# Altium schematic sheet conn_board_power.SchDoc
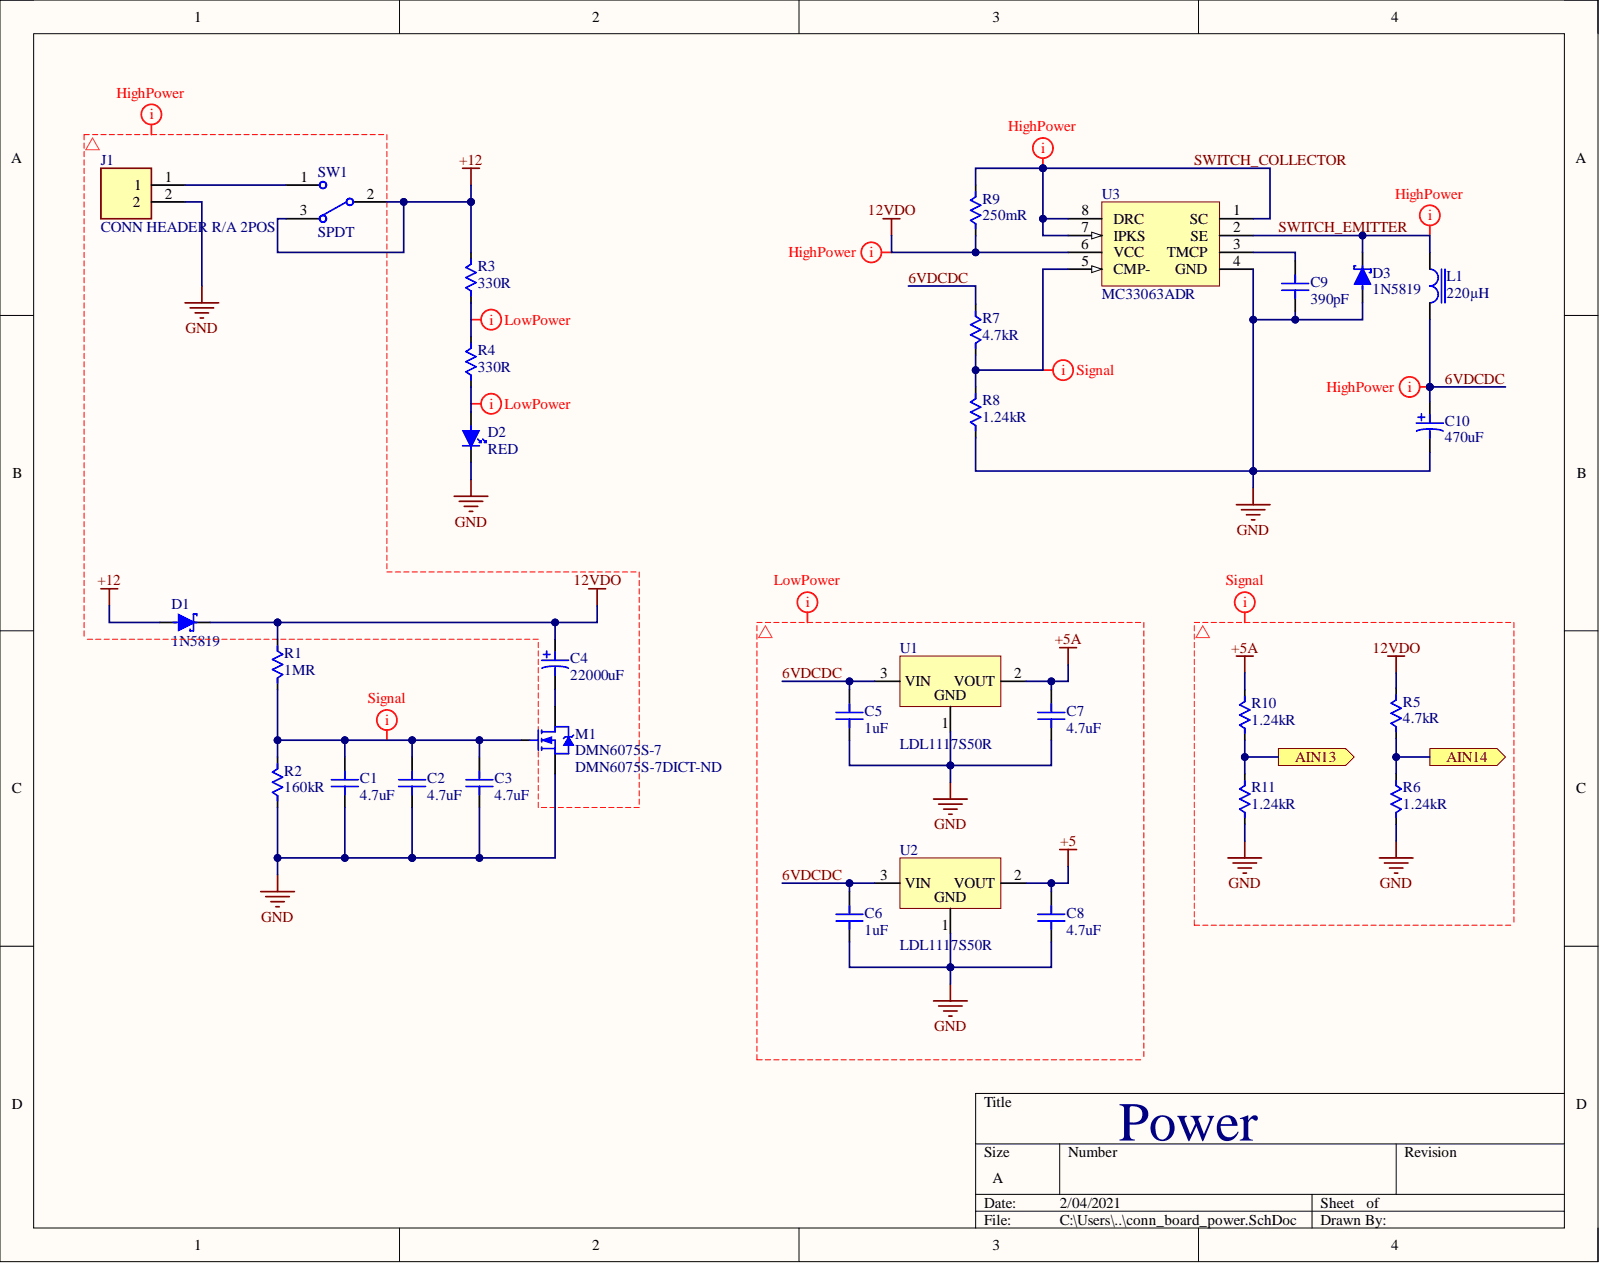
[diagram p0: conn_board_power.SchDoc]

## Parts
C1  4.7uF  [CAP_0805]
C2  4.7uF  [CAP_0805]
C3  4.7uF  [CAP_0805]
C4  22000uF  [UVR1C223MRD6_BENT_F]
C5  1uF  [CAP_0805]
C6  1uF  [CAP_0805]
C7  4.7uF  [CAP_0805]
C8  4.7uF  [CAP_0805]
C9  390pF  [CAP_0805]
C10  470uF  [UUD1C471MNL1GS]
D1  1N5819  [SOD123]
D2  RED  [150080SS75000]
D3  1N5819  [SOD123]
J1  CONN HEADER R/A 2POS  [2 POS MOLEX]
L1  220µH  [INDP125125X600N]
M1  DMN6075S-7  Supplier Part Number 1: DMN6075S-7DICT-ND  [FP-SOT23-MFG]
R1  1MR  [RES_0805_PANASONIC]
R2  160kR  [RES_0805_PANASONIC]
R3  330R  [RES_0805_PANASONIC]
R4  330R  [RES_0805_PANASONIC]
R5  4.7kR  [RES_0805_PANASONIC]
R6  1.24kR  [RES_0805_PANASONIC]
R7  4.7kR  [RES_0805_PANASONIC]
R8  1.24kR  [RES_0805_PANASONIC]
R9  250mR  [CSR1206FKR250]
R10  1.24kR  [RES_0805_PANASONIC]
R11  1.24kR  [RES_0805_PANASONIC]
SW1  SPDT  [100SP1T2B4M6QE]
U1  LDL1117S50R  [SOT230P700X180-4N]
U2  LDL1117S50R  [SOT230P700X180-4N]
U3  MC33063ADR  [SOIC_8]

## Ports
AIN13, AIN14

## Nets
12VDO: C4.1 D1.1 D1.2 R1.1 R3.2 R5.2 R9.1 SW1.2 SW1.3 U3.6(VCC)
+5: C8.2 U2.2
+5A: C7.2 R10.2 U1.2
GND: C1.1 C2.1 C3.1 C5.1 C6.1 C7.1 C8.1 C9.1 C10.2 D2.1 D3.2 J1.2 M1.S R2.1 R6.2 R8.1 R11.1 U1.1 U2.1 U3.4(GND)
6VDCDC: C5.2 C6.2 C10.1 L1.1 R7.1 U1.3 U2.3
AIN13: R10.1 R11.2 ; port AIN13
AIN14: R5.1 R6.1 ; port AIN14
SWITCH_COLLECTOR: R9.2 U3.1(SC) U3.7(IPKS) U3.8(DRC)
SWITCH_EMITTER: D3.1 L1.2 U3.2(SE)
NetC1_2: C1.2 C2.2 C3.2 M1.G R1.2 R2.2
NetC4_2: C4.2 M1.D
NetC9_2: C9.2 U3.3(TMCP)
NetD2_2: D2.2 R4.1
NetJ1_1: J1.1 SW1.1
NetR3_1: R3.1 R4.2
NetR7_2: R7.2 R8.2 U3.5(CMP-)

## Library data of the parts
C1-C3 C7 C8 = CL21A475KAQNNNE: SAMSUNG ELECTRO-MECHANICS - CL21A475KAQNNNE - SMD Multilayer Ceramic Capacitor, 4.7 µF, 25 V, 0805 [2012 Metric], ± 10%, X5R, CL Series; Voltage Rating: 25V; Capacitance: 4.7uF; Case Code (Imperial): 0805; Case Code (Metric): 2012; Case/Package: 0805; Category: Ceramic Capacitors; Component Kind: Standard; ComponentLink1Description: https://datasheet.ciiva.com/25798/cl21a475kaqnnne-25798882.pdf?src-supplier=Digi-Key; ComponentLink1URL: https://datasheet.ciiva.com/25798/cl21a475kaqnnne-25798882.pdf?src-supplier=Digi-Key; Depth: 1.25mm; Dielectric: X5R; Height: 1.25mm; Lead Free: Lead Free; Length: 2mm; Library Name: Schlib1.SchLib; Manufacturer: Samsung; Manufacturer Part Number: CL21A475KAQNNNE; Max Operating Temperature: 85°C; Min Operating Temperature: -55°C; Mount: Surface Mount; Package Quantity: 1; Packaging: Tape and Reel; Pricing 1: 1=0.11000, 10=0.08100, 50=0.04600, 100=0.03820, 500=0.02678, 1000=0.02216 (USD); Radiation Hardening: No; RoHS: Yes; Stock 1: 671990; Supplier 1: Digi-Key; Supplier Part Number 1: 1276-1244-1-ND; Thickness: 0.055inch; Tolerance: 10%; Voltage Rating (DC): 25V; Width: 0.049inch
C4 = UVR1C223MRD6: Cap Aluminum 22000uF 16V 20% (25 X 40mm) Radial 12.5mm 4000mA 2000 hr 85°C Bulk; Manufacturer: Nichicon; Capacitance: 22mF; Dielectric Material: Aluminium; Dissipation Factor: 0.2%; Lead Diameter: 1mm; Leakage Current: 10.56mA; Life (Hours): 2000Hour; Ripple Current: 4A; Case/Package: Radial; Category: Capacitor; Component Kind: Standard; ComponentLink1Description: https://datasheet.ciiva.com/17515/e-vr-17515877.pdf?src-supplier=Avnet+Europe; ComponentLink1URL: https://datasheet.ciiva.com/17515/e-vr-17515877.pdf?src-supplier=Avnet+Europe; ComponentLink2Description: https://datasheet.ciiva.com/118/e-vr-118733.pdf?src-supplier=Digi-Key; ComponentLink2URL: https://datasheet.ciiva.com/118/e-vr-118733.pdf?src-supplier=Digi-Key; ComponentLink3Description: http://datasheet.octopart.com/UVR1C223MRD6-Nichicon-datasheet-2149.pdf?src-supplier=Digi-Key; ComponentLink3URL: http://datasheet.octopart.com/UVR1C223MRD6-Nichicon-datasheet-2149.pdf?src-supplier=Digi-Key; ComponentLink4Description: http://datasheet.octopart.com/UVR1C223MRD6-Nichicon-datasheet-12518647.pdf?src-supplier=Newark; ComponentLink4URL: http://datasheet.octopart.com/UVR1C223MRD6-Nichicon-datasheet-12518647.pdf?src-supplier=Newark; ComponentLink5Description: http://datasheet.octopart.com/UVR1C223MRD6-Nichicon-datasheet-24896455.pdf?src-supplier=TME; ComponentLink5URL: http://datasheet.octopart.com/UVR1C223MRD6-Nichicon-datasheet-24896455.pdf?src-supplier=TME; ComponentLink6Description: http://datasheet.octopart.com/UVR1C223MRD6-Nichicon-datasheet-41169141.pdf?src-supplier=Newark; ComponentLink6URL: http://datasheet.octopart.com/UVR1C223MRD6-Nichicon-datasheet-41169141.pdf?src-supplier=Newark; ComponentLink7Description: http://datasheet.octopart.com/UVR1C223MRD6-Nichicon-datasheet-58177256.pdf?src-supplier=Newark; ComponentLink7URL: http://datasheet.octopart.com/UVR1C223MRD6-Nichicon-datasheet-58177256.pdf?src-supplier=Newark; Contact Plating: Tin; Diameter: 25mm; Height: 40mm; Height - Seated (Max): 1.575inch; Lead Free: Lead Free; Lead Pitch: 12.5mm; Length: 40mm; Library Name: Schlib1.SchLib; Manufacturer Part Number: UVR1C223MRD6; Max Operating Temperature: 85°C; Min Operating Temperature: -40°C; Mount: Through Hole; Number of Pins: 2; Package Quantity: 180; Packaging: Bulk; Pricing 1: 1=3.85000, 10=3.46700, 50=3.00440, 100=2.61910, 500=2.15694, 1000=2.00287, 2500=1.96436, 5000=1.92584 (USD); Radiation Hardening: No; Stock 1: 153; Supplier 1: Digi-Key; Supplier Part Number 1: 493-1054-ND; Termination: Radial; Tolerance: 20%; Voltage Rating: 16V; Voltage Rating (DC): 16V
C5 C6 = C0805C105Z4VACTU: Cap Ceramic 1uF 16V Y5V -20% to 80% Pad SMD 0805 85C T/R; Manufacturer: KEMET; Dissipation Factor: 7%; Capacitance: 1uF; Case Code (Imperial): 0805; Case Code (Metric): 2012; Case/Package: 0805; Category: Capacitor; Component Kind: Standard; ComponentLink1Description: https://datasheet.ciiva.com/6074/1698780-6074369.pdf?src-supplier=Farnell; ComponentLink1URL: https://datasheet.ciiva.com/6074/1698780-6074369.pdf?src-supplier=Farnell; ComponentLink2Description: https://datasheet.ciiva.com/16623/datasheetpn-c0805c105z4vac7800-16623623.pdf?src-supplier=Avnet+Europe; ComponentLink2URL: https://datasheet.ciiva.com/16623/datasheetpn-c0805c105z4vac7800-16623623.pdf?src-supplier=Avnet+Europe; ComponentLink3Description: https://datasheet.ciiva.com/14604/70095383-14604106.pdf?src-supplier=Allied+Electronics; ComponentLink3URL: https://datasheet.ciiva.com/14604/70095383-14604106.pdf?src-supplier=Allied+Electronics; ComponentLink4Description: https://datasheet.ciiva.com/7600/1698780-7600029.pdf?src-supplier=Newark; ComponentLink4URL: https://datasheet.ciiva.com/7600/1698780-7600029.pdf?src-supplier=Newark; ComponentLink5Description: https://datasheet.ciiva.com/14589/70095383-14589407.pdf?src-supplier=Allied+Electronics; ComponentLink5URL: https://datasheet.ciiva.com/14589/70095383-14589407.pdf?src-supplier=Allied+Electronics; ComponentLink6Description: http://datasheet.octopart.com/C0805C105Z4VACTU-Kemet-datasheet-13692877.pdf?src-supplier=Element14; ComponentLink6URL: http://datasheet.octopart.com/C0805C105Z4VACTU-Kemet-datasheet-13692877.pdf?src-supplier=Element14; ComponentLink7Description: http://datasheet.octopart.com/C0805C105Z4VACTU-Kemet-datasheet-503297.pdf?src-supplier=Newark; ComponentLink7URL: http://datasheet.octopart.com/C0805C105Z4VACTU-Kemet-datasheet-503297.pdf?src-supplier=Newark; ComponentLink8Description: http://datasheet.octopart.com/C0805C105Z4VACTU-KEMET-datasheet-101576167.pdf?src-supplier=Farnell; ComponentLink8URL: http://datasheet.octopart.com/C0805C105Z4VACTU-KEMET-datasheet-101576167.pdf?src-supplier=Farnell; ComponentLink9Description: http://datasheet.octopart.com/C0805C105Z4VACTU-KEMET-datasheet-83957834.pdf?src-supplier=TME; ComponentLink9URL: http://datasheet.octopart.com/C0805C105Z4VACTU-KEMET-datasheet-83957834.pdf?src-supplier=TME; ComponentLink10Description: http://datasheet.octopart.com/C0805C105Z4VACTU-Kemet-datasheet-5313890.pdf?src-supplier=Verical; ComponentLink10URL: http://datasheet.octopart.com/C0805C105Z4VACTU-Kemet-datasheet-5313890.pdf?src-supplier=Verical; ComponentLink11Description: http://datasheet.octopart.com/C0805C105Z4VAC-TU-Kemet-datasheet-8204015.pdf?src-supplier=Jameco; ComponentLink11URL: http://datasheet.octopart.com/C0805C105Z4VAC-TU-Kemet-datasheet-8204015.pdf?src-supplier=Jameco; ComponentLink12Description: http://datasheet.octopart.com/C0805C105Z4VACTU-Kemet-datasheet-464.pdf?src-supplier=Allied+Electronics; ComponentLink12URL: http://datasheet.octopart.com/C0805C105Z4VACTU-Kemet-datasheet-464.pdf?src-supplier=Allied+Electronics; ComponentLink13Description: http://datasheet.octopart.com/C0805C105Z4VACTU-Kemet-datasheet-11554470.pdf?src-supplier=Arrow; ComponentLink13URL: http://datasheet.octopart.com/C0805C105Z4VACTU-Kemet-datasheet-11554470.pdf?src-supplier=Arrow; ComponentLink14Description: http://datasheet.octopart.com/C0805C105Z4VACTU-Kemet-datasheet-66128697.pdf?src-supplier=Element14; ComponentLink14URL: http://datasheet.octopart.com/C0805C105Z4VACTU-Kemet-datasheet-66128697.pdf?src-supplier=Element14; ComponentLink15Description: http://datasheet.octopart.com/C0805C105Z4VACTU-Kemet-datasheet-66325528.pdf?src-supplier=Newark; ComponentLink15URL: http://datasheet.octopart.com/C0805C105Z4VACTU-Kemet-datasheet-66325528.pdf?src-supplier=Newark; ComponentLink16Description: http://datasheet.octopart.com/C0805C105Z4VACTU-Kemet-datasheet-67847115.pdf?src-supplier=TME; ComponentLink16URL: http://datasheet.octopart.com/C0805C105Z4VACTU-Kemet-datasheet-67847115.pdf?src-supplier=TME; ComponentLink17Description: http://datasheet.octopart.com/C0805C105Z4VACTU-KEMET-datasheet-84169754.pdf; ComponentLink17URL: http://datasheet.octopart.com/C0805C105Z4VACTU-KEMET-datasheet-84169754.pdf; ComponentLink18Description: http://datasheet.octopart.com/C0805C105Z4VACTU-KEMET-datasheet-41193421.pdf?src-supplier=Master+Electronics; ComponentLink18URL: http://datasheet.octopart.com/C0805C105Z4VACTU-KEMET-datasheet-41193421.pdf?src-supplier=Master+Electronics; Contact Material: Tin; Depth: 1.25mm; Dielectric: Y5V; Dielectric Material: Ceramic; Height: 1.25mm; Lead Free: Lead Free; Lead Pitch: 0.75mm; Length: 2mm; Library Name: Schlib1.SchLib; Manufacturer Part Number: C0805C105Z4VACTU; Max Operating Temperature: 85°C; Min Operating Temperature: -30°C; Mount: Surface Mount; Number of Pins: 2; Package Quantity: 1; Packaging: Tape and Reel; Pricing 1: 1=0.11000, 10=0.08100, 50=0.04420, 100=0.03620, 500=0.02584, 1000=0.02030 (USD); Radiation Hardening: No; REACH SVHC: No SVHC; Stock 1: 83211; Supplier 1: Digi-Key; Supplier Part Number 1: 399-8011-1-ND; Termination: SMD/SMT; Thickness: 0.055inch; Tolerance: 80%; Voltage: 16V; Voltage Rating: 16V; Voltage Rating (DC): 16V; Width: 0.049inch
C9 = CL21C391JBANNNC: Cap Ceramic 390pF 50V C0G 5% SMD 0805 125C Paper T/R; Manufacturer: Samsung; Temperature Coefficient: 300ppm/°C; Capacitance: 390pF; Case Code (Imperial): 0805; Case Code (Metric): 2012; Case/Package: 0805; Category: Ceramic Capacitors; Component Kind: Standard; ComponentLink1Description: https://datasheet.ciiva.com/25733/cl21c391jbannnc-25733106.pdf?src-supplier=Digi-Key; ComponentLink1URL: https://datasheet.ciiva.com/25733/cl21c391jbannnc-25733106.pdf?src-supplier=Digi-Key; ComponentLink2Description: https://datasheet.ciiva.com/26838/getdatasheetpartid-687114-26838793.pdf?src-supplier=Verical; ComponentLink2URL: https://datasheet.ciiva.com/26838/getdatasheetpartid-687114-26838793.pdf?src-supplier=Verical; ComponentLink3Description: https://datasheet.ciiva.com/29200/453712-da-01-en-vs-kondensator-0805-29200047.pdf?src-supplier=Conrad; ComponentLink3URL: https://datasheet.ciiva.com/29200/453712-da-01-en-vs-kondensator-0805-29200047.pdf?src-supplier=Conrad; ComponentLink4Description: https://datasheet.ciiva.com/16448/cl05b104kq5nnnc-16448985.pdf?src-supplier=Future+Electronics; ComponentLink4URL: https://datasheet.ciiva.com/16448/cl05b104kq5nnnc-16448985.pdf?src-supplier=Future+Electronics; Construction: Flat; Depth: 1.25mm; Dielectric: C0G; Height: 0.65mm; Length: 2mm; Library Name: Schlib1.SchLib; Manufacturer Part Number: CL21C391JBANNNC; Material: Ceramic; Max Operating Temperature: 125°C; Min Operating Temperature: -55°C; Mount: Surface Mount; Package Quantity: 1; Packaging: Tape and Reel; Pricing 1: 1=0.11000, 10=0.08400, 50=0.04580, 100=0.03740, 500=0.02668, 1000=0.02096 (USD); Radiation Hardening: No; RoHS: Yes; RoHS Compliant: Yes; Series: CL; Stock 1: 98292; Supplier 1: Digi-Key; Supplier Part Number 1: 1276-1303-1-ND; Thickness: 0.03inch; Tolerance: 5%; Voltage: 50V; Voltage Rating: 50V; Width: 0.049inch
C10 = UUD1C471MNL1GS: NICHICON   UUD1C471MNL1GS   CAP, ALU ELEC, 470UF, 16V, SMD; Dielectric Material: Aluminium; Dissipation Factor: 0.2%; Impedance: 170mR; Lead Diameter: 1.1mm; Leakage Current: 3uA; Life (Hours): 5000Hour; Ripple Current: 450mA; Tolerance: 20%; Capacitance: 470uF; Case/Package: Radial; Category: Capacitor; Component Kind: Standard; ComponentLink1Description: https://datasheet.ciiva.com/11781/0900766b80fa39e7-11781894.pdf?src-supplier=RSComponents; ComponentLink1URL: https://datasheet.ciiva.com/11781/0900766b80fa39e7-11781894.pdf?src-supplier=RSComponents; ComponentLink2Description: https://datasheet.ciiva.com/97/e-ud-97896.pdf?src-supplier=Digi-Key; ComponentLink2URL: https://datasheet.ciiva.com/97/e-ud-97896.pdf?src-supplier=Digi-Key; ComponentLink3Description: https://datasheet.ciiva.com/7781/66055-7781448.pdf?src-supplier=Element14; ComponentLink3URL: https://datasheet.ciiva.com/7781/66055-7781448.pdf?src-supplier=Element14; ComponentLink4Description: https://datasheet.ciiva.com/97/e-ud-97897.pdf?src-supplier=Digi-Key; ComponentLink4URL: https://datasheet.ciiva.com/97/e-ud-97897.pdf?src-supplier=Digi-Key; ComponentLink5Description: https://datasheet.ciiva.com/22693/66055-22693551.pdf?src-supplier=Element14; ComponentLink5URL: https://datasheet.ciiva.com/22693/66055-22693551.pdf?src-supplier=Element14; ComponentLink6Description: https://datasheet.ciiva.com/97/e-ud-97898.pdf?src-supplier=Digi-Key; ComponentLink6URL: https://datasheet.ciiva.com/97/e-ud-97898.pdf?src-supplier=Digi-Key; ComponentLink7Description: https://datasheet.ciiva.com/11685/e-ud-11685293.pdf?src-supplier=Avnet; ComponentLink7URL: https://datasheet.ciiva.com/11685/e-ud-11685293.pdf?src-supplier=Avnet; ComponentLink8Description: https://datasheet.ciiva.com/16502/e-ud-16502751.pdf?src-supplier=Future+Electronics; ComponentLink8URL: https://datasheet.ciiva.com/16502/e-ud-16502751.pdf?src-supplier=Future+Electronics; Contact Plating: Tin; Diameter: 8mm; Height: 10mm; Height - Seated (Max): 0.394inch; Lead Free: Lead Free; Lead Pitch: 3.1mm; Length: 10mm; Library Name: Schlib1.SchLib; Manufacturer: Nichicon; Manufacturer Part Number: UUD1C471MNL1GS; Max Operating Temperature: 105°C; Min Operating Temperature: -55°C; Number of Pins: 2; Package Quantity: 500; Packaging: Tape and Reel; Pricing 1: 1=0.69000, 10=0.50800, 25=0.43560, 100=0.34850, 250=0.31948 (USD); Radiation Hardening: No; REACH SVHC: No SVHC; Ripple Current (AC): 450mA; RoHS: Yes; Stock 1: 11602; Supplier 1: Digi-Key; Supplier Part Number 1: 493-2266-1-ND; Termination: SMD/SMT; Voltage: 16V; Voltage Rating: 16V; Voltage Rating (DC): 16V
D1 D3 = 1N5819HW-7-F: DIODES INC. - 1N5819HW-7-F - DIODE, SCKY RECTI, 1A, 40V, SOD123; Capacitance: 110pF; Element Configuration: Single; Forward Voltage: 450mV; Max Forward Surge Current (Ifsm): 25A; Max Power Dissipation: 450mW; Peak Reverse Current: 50uA; Polarity: Standard; Average Rectified Current: 1A; Case/Package: SOD-123-2; Category: Diode; Component Kind: Standard; ComponentLink1Description: https://datasheet.ciiva.com/16303/ds30217-16303296.pdf?src-supplier=Future+Electronics; ComponentLink1URL: https://datasheet.ciiva.com/16303/ds30217-16303296.pdf?src-supplier=Future+Electronics; ComponentLink2Description: https://datasheet.ciiva.com/27092/1538419-27092484.pdf?src-supplier=Jameco; ComponentLink2URL: https://datasheet.ciiva.com/27092/1538419-27092484.pdf?src-supplier=Jameco; ComponentLink3Description: https://datasheet.ciiva.com/912/ds30217-912801.pdf?src-supplier=Digi-Key; ComponentLink3URL: https://datasheet.ciiva.com/912/ds30217-912801.pdf?src-supplier=Digi-Key; ComponentLink4Description: https://datasheet.ciiva.com/1177/ds30217-1177089.pdf?src-supplier=Element14; ComponentLink4URL: https://datasheet.ciiva.com/1177/ds30217-1177089.pdf?src-supplier=Element14; ComponentLink5Description: https://datasheet.ciiva.com/912/ds30217-912802.pdf?src-supplier=Digi-Key; ComponentLink5URL: https://datasheet.ciiva.com/912/ds30217-912802.pdf?src-supplier=Digi-Key; ComponentLink6Description: https://datasheet.ciiva.com/912/ds30217-912803.pdf?src-supplier=Digi-Key; ComponentLink6URL: https://datasheet.ciiva.com/912/ds30217-912803.pdf?src-supplier=Digi-Key; ComponentLink7Description: https://datasheet.ciiva.com/8303/ds30217-8303132.pdf?src-supplier=Avnet; ComponentLink7URL: https://datasheet.ciiva.com/8303/ds30217-8303132.pdf?src-supplier=Avnet; ComponentLink8Description: https://datasheet.ciiva.com/25919/ds30217-25919644.pdf?src-supplier=Avnet+Europe; ComponentLink8URL: https://datasheet.ciiva.com/25919/ds30217-25919644.pdf?src-supplier=Avnet+Europe; Contact Plating: Tin,Matte; Current Rating: 1A; Forward Current: 1A; Height: 1.25mm; Lead Free: Lead Free; Length: 2.85mm; Library Name: Schlib1.SchLib; Manufacturer: Diodes; Manufacturer Part Number: 1N5819HW-7-F; Max Operating Temperature: 125°C; Max Repetitive Reverse Voltage (Vrrm): 40V; Max Reverse Voltage (DC): 40V; Min Operating Temperature: -65°C; Number of Pins: 2; Output Current: 1A; Package Quantity: 3000; Packaging: Tape and Reel; Peak Non-Repetitive Surge Current: 25A; Pricing 1: 1=0.40000, 10=0.30100, 25=0.26360, 100=0.14310, 500=0.11678, 1000=0.08664 (USD); Radiation Hardening: No; REACH SVHC: No SVHC; RoHS: Yes; Stock 1: 502732; Supplier 1: Digi-Key; Supplier Part Number 1: 1N5819HW-FDICT-ND; Termination: SMD/SMT; Voltage Rating (DC): 40V; Weight: 0.000353oz; Width: 1.7mm
D2 = 150080SS75000: WURTH ELEKTRONIK   150080SS75000   LED, 0805, SUP RED, 60MCD, 630NM; Manufacturer: Wurth Electronics; Forward Current: 30mA; Forward Voltage: 1.9V; Lens Style: Clear,Rectangular; Test Current: 20mA; Viewing Angle: 140°; Case Code (Imperial): 0805; Case Code (Metric): 2012; Case/Package: 0805; Category: LEDs; Color: Red; Component Kind: Standard; ComponentLink1Description: https://datasheet.ciiva.com/22656/150080ss75000-22656963.pdf?src-supplier=Element14; ComponentLink1URL: https://datasheet.ciiva.com/22656/150080ss75000-22656963.pdf?src-supplier=Element14; ComponentLink2Description: https://datasheet.ciiva.com/29279/759436-da-01-en-chipled-0805-w-kl-s-rot-150080ss75000-29279211.pdf?src-supplier=Conrad; ComponentLink2URL: https://datasheet.ciiva.com/29279/759436-da-01-en-chipled-0805-w-kl-s-rot-150080ss75000-29279211.pdf?src-supplier=Conrad; ComponentLink3Description: https://datasheet.ciiva.com/29563/150080ss75000-29563014.pdf?src-supplier=Digi-Key; ComponentLink3URL: https://datasheet.ciiva.com/29563/150080ss75000-29563014.pdf?src-supplier=Digi-Key; ComponentLink4Description: https://datasheet.ciiva.com/29563/150080ss75000-29563015.pdf?src-supplier=Digi-Key; ComponentLink4URL: https://datasheet.ciiva.com/29563/150080ss75000-29563015.pdf?src-supplier=Digi-Key; ComponentLink5Description: https://datasheet.ciiva.com/29563/150080ss75000-29563013.pdf?src-supplier=Digi-Key; ComponentLink5URL: https://datasheet.ciiva.com/29563/150080ss75000-29563013.pdf?src-supplier=Digi-Key; Dominant Wavelength: 630nm; Height: 0.7mm; Length: 2mm; Library Name: Schlib1.SchLib; Luminous Intensity: 60mcd; Manufacturer Part Number: 150080SS75000; Max Operating Temperature: 85°C; Min Operating Temperature: -40°C; Mount: Surface Mount; Package Quantity: 4000; Packaging: Tape and Reel; Pricing 1: 1=0.18000, 50=0.16500, 100=0.14200, 500=0.12300 (USD); REACH SVHC: Unknown; RoHS: Yes; Stock 1: 6006; Supplier 1: Digi-Key; Supplier Part Number 1: 732-4985-1-ND; Wavelength: 630nm; Width: 1.25mm
J1 = 1724480002: Manufacturer: Molex; Connector Type: Male Pin; Glow Wire Compliant: Compliant; Number of Circuits: 2; Row Spacing: 0.165inch; Category: Headers and Wire Housings; Color: Black; Component Kind: Standard; ComponentLink1Description: https://www.molex.com/pdm_docs/sd/1724480002_sd.pdf?src-supplier=Digi-Key; ComponentLink1URL: https://www.molex.com/pdm_docs/sd/1724480002_sd.pdf?src-supplier=Digi-Key; Contact Plating: Tin; Description: CONN HEADER R/A 2POS; Fastening Type: Ramp; Library Name: Schlib1.SchLib; Manufacturer Part Number: 1724480002; Mount: Through Hole; Number of Pins: 2; Number of Positions: 2; Number of Rows: 2; Orientation: Right Angle; Packaging: Tray; Pricing 1: 1=0.55000, 10=0.46000, 100=0.35780, 1000=0.28116, 5000=0.24708, 10000=0.23004 (USD); RoHS: No; Stock 1: 6210; Supplier 1: Digi-Key; Supplier Part Number 1: WM16206-ND; Termination: Solder
L1 = SRR1260-221K: BOURNS   SRR1260-221K   INDUCTOR, SHIELDED, 220UH, 1.3A, SMD; Core Material: Ferrite; Current Rating: 1.38A; DC Resistance (DCR): 380mR; Frequency: 4.2MHz; Inductance Tolerance: 10%; Material: Steel; MF: Bourns - J.W. Miller; Q Factor: 22; Test Frequency: 100kHz; Availability: Unavailable; Case/Package: 1260; Category: Inductor; Component Kind: Standard; ComponentLink1Description: https://datasheet.ciiva.com/11800/0900766b80defded-11800204.pdf?src-supplier=RSComponents; ComponentLink1URL: https://datasheet.ciiva.com/11800/0900766b80defded-11800204.pdf?src-supplier=RSComponents; ComponentLink2Description: https://datasheet.ciiva.com/7838/1430852-7838981.pdf?src-supplier=Element14; ComponentLink2URL: https://datasheet.ciiva.com/7838/1430852-7838981.pdf?src-supplier=Element14; ComponentLink3Description: https://datasheet.ciiva.com/1050/srr1260-1050007.pdf?src-supplier=Digi-Key; ComponentLink3URL: https://datasheet.ciiva.com/1050/srr1260-1050007.pdf?src-supplier=Digi-Key; ComponentLink4Description: https://datasheet.ciiva.com/17111/70277056-17111848.pdf?src-supplier=Allied+Electronics; ComponentLink4URL: https://datasheet.ciiva.com/17111/70277056-17111848.pdf?src-supplier=Allied+Electronics; ComponentLink5Description: https://datasheet.ciiva.com/23793/1914016-23793576.pdf?src-supplier=Newark; ComponentLink5URL: https://datasheet.ciiva.com/23793/1914016-23793576.pdf?src-supplier=Newark; ComponentLink6Description: https://datasheet.ciiva.com/17285/srr1260-17285833.pdf?src-supplier=Avnet+Europe; ComponentLink6URL: https://datasheet.ciiva.com/17285/srr1260-17285833.pdf?src-supplier=Avnet+Europe; ComponentLink7Description: https://datasheet.ciiva.com/8517/srr1260-8517134.pdf?src-supplier=Avnet; ComponentLink7URL: https://datasheet.ciiva.com/8517/srr1260-8517134.pdf?src-supplier=Avnet; ComponentLink8Description: https://datasheet.ciiva.com/22618/70796-22618159.pdf?src-supplier=Element14; ComponentLink8URL: https://datasheet.ciiva.com/22618/70796-22618159.pdf?src-supplier=Element14; ComponentLink9Description: https://datasheet.ciiva.com/28679/1430852-28679711.pdf?src-supplier=Element14; ComponentLink9URL: https://datasheet.ciiva.com/28679/1430852-28679711.pdf?src-supplier=Element14; ComponentLink10Description: https://datasheet.ciiva.com/1050/srr1260-1050006.pdf?src-supplier=Digi-Key; ComponentLink10URL: https://datasheet.ciiva.com/1050/srr1260-1050006.pdf?src-supplier=Digi-Key; ComponentLink11Description: https://datasheet.ciiva.com/1050/srr1260-1050008.pdf?src-supplier=Digi-Key; ComponentLink11URL: https://datasheet.ciiva.com/1050/srr1260-1050008.pdf?src-supplier=Digi-Key; DC Current: 1.38A; Depth: 12.8mm; Height: 6mm; Height - Seated (Max): 0.256inch; Inductance: 220uH; Length: 12.5mm; Library Name: Schlib1.SchLib; Manufacturer: Bourns; Manufacturer Part Number: SRR1260-221K; Max DC Current: 1.38A; Max Operating Temperature: 125°C; Military Standard: Not; Min Operating Temperature: -40°C; Mount: Surface Mount; MP: SRR1260-221K; Number of Pins: 2; Package: Nonstandard Bourns - J.W. Miller; Package Quantity: 600; Packaging: Tape and Reel; Price: None; Pricing 1: 1=1.09000, 10=1.00800, 25=0.93000, 50=0.77500, 100=0.72850, 250=0.65100 (USD); REACH SVHC: No SVHC; Resistance: 380MR; Resistor Type: Wirewound; RMS Current (Irms): 1.38A; RoHS: Yes; Saturation Current: 1.3A; Self Resonant Frequency: 4.2MHz; Shielding: Shielded; SnapEDA_Link: https://www.snapeda.com/parts/SRR1260-221K/Bourns%20-%20J.W.%20Miller/view-part/50181/?ref=snap; Stock 1: 17151; Supplier 1: Digi-Key; Supplier Part Number 1: SRR1260-221KCT-ND; Termination: SMD/SMT; Tolerance: 10%; Width: 12.5mm
M1 = CMP-12801-000014-1: MOSFET 60V N-Ch Enh FET 20Vgss 0.8W 600pF; Manufacturer: Diodes Inc.; Automotive Grade: Grade 0; Continuous Drain Current: 2A; Depletion Mode: False; Drain To Source Resistance: 69mΩ; Gate Charge At Vgs: 12.3nC @ 10V; Gate To Source Voltage: 20V; Input Capacitace At Vds: 606pF @ 20V; Input Capacitance: 606pF; Max Forward Diode Voltage: 1.2V; Max Junction Temp: +150°C; Max Power Dissipation: 800mW; Number Of Channels: 1; Pulse Drain Current: 12A; Rds On Max: 85mR; Rthja Max: 157°C/W; Standoff Height: 0.013mm; Threshold Vgs Max: 3V; Threshold Vgs Min: 1V; Turn Off Delay Time: 35ns; Turn On Delay Time: 3.5ns; Automotive: Yes; Case/Package: TO-236-3; Category: Trans; Component Kind: Standard; ComponentLink1Description: http://www.mouser.com/ds/2/115/DMN6075S-532804.pdf?src-supplier=Mouser; ComponentLink1URL: http://www.mouser.com/ds/2/115/DMN6075S-532804.pdf?src-supplier=Mouser; ComponentLink2Description: Datasheet; ComponentLink2URL: https://www.diodes.com/assets/Datasheets/DMN6075S.pdf; ComponentLink3Description: https://www.diodes.com/assets/Datasheets/DMN6075S.pdf?src-supplier=Digi-Key; ComponentLink3URL: https://www.diodes.com/assets/Datasheets/DMN6075S.pdf?src-supplier=Digi-Key; Continuous Drain Current (ID): 2A; Device Class L1: Discrete Semiconductors; Device Class L2: Transistors; Device Class L3: MOSFETs; Digikey Description: MOSFET N-CH 60V 2A SOT23-3; Drain To Source Breakdown Voltage: 60V; Drain To Source Voltage: 60V; Drain to Source Voltage (Vdss): 60V; Footprint Url: https://www.diodes.com/assets/Package-Files/SOT23.pdf; Height: 1.1mm; Lead Free: Yes; Library Name: Schlib1.SchLib; Manufacturer Part Number: DMN6075S-7; Max Operating Temperature: 150°C; Min Operating Temperature: -55°C; Mount: Surface Mount; Mouser Description: MOSFET 60V N-Ch Enh FET 20Vgss 0.8W 600pF; Number Of N Channels: 1; Octopart: https://octopart.com/dmn6075s-7-diodes+inc.-55697882; Package: SOT23; Packaging: Tape and Reel; Power Dissipation: 0.8W; Pricing 1: 1=0.33000, 10=0.23000, 25=0.21440, 100=0.11630, 500=0.09486, 1000=0.07038 (USD); RoHS: TRUE; Stock 1: 363651; Supplier 1: Digi-Key; Temperature: -55°C to +150°C; Temperature Range High: +150°C; Temperature Range Low: -55°C
R1 = ERJ-6ENF1004V: Res Thick Film 0805 1M Ohm 1% 1/8W ±100ppm/°C Molded SMD Punched Carrier T/R; Manufacturer: Panasonic; Max Power Dissipation: 125mW; Voltage Rating: 150V; Case Code (Imperial): 0805; Case Code (Metric): 2012; Case/Package: 0805; Category: Resistor; Component Kind: Standard; ComponentLink1Description: https://datasheet.ciiva.com/11821/0900766b80f80784-11821573.pdf?src-supplier=RSComponents; ComponentLink1URL: https://datasheet.ciiva.com/11821/0900766b80f80784-11821573.pdf?src-supplier=RSComponents; ComponentLink2Description: https://datasheet.ciiva.com/16761/70260748-16761141.pdf?src-supplier=Allied+Electronics; ComponentLink2URL: https://datasheet.ciiva.com/16761/70260748-16761141.pdf?src-supplier=Allied+Electronics; ComponentLink3Description: https://datasheet.ciiva.com/22749/426256-22749637.pdf?src-supplier=Element14; ComponentLink3URL: https://datasheet.ciiva.com/22749/426256-22749637.pdf?src-supplier=Element14; ComponentLink4Description: https://datasheet.ciiva.com/30161/aoa0000ce2-30161525.pdf?src-supplier=Onlinecomponents.com; ComponentLink4URL: https://datasheet.ciiva.com/30161/aoa0000ce2-30161525.pdf?src-supplier=Onlinecomponents.com; ComponentLink5Description: https://datasheet.ciiva.com/48532/aoa0000ce2-48532149.pdf?src-supplier=Avnet; ComponentLink5URL: https://datasheet.ciiva.com/48532/aoa0000ce2-48532149.pdf?src-supplier=Avnet; ComponentLink6Description: https://datasheet.ciiva.com/8079/426256-8079622.pdf?src-supplier=Element14; ComponentLink6URL: https://datasheet.ciiva.com/8079/426256-8079622.pdf?src-supplier=Element14; ComponentLink7Description: https://datasheet.ciiva.com/27055/1988997-27055735.pdf?src-supplier=Jameco; ComponentLink7URL: https://datasheet.ciiva.com/27055/1988997-27055735.pdf?src-supplier=Jameco; ComponentLink8Description: https://datasheet.ciiva.com/5868/426256-5868184.pdf?src-supplier=Farnell; ComponentLink8URL: https://datasheet.ciiva.com/5868/426256-5868184.pdf?src-supplier=Farnell; ComponentLink9Description: https://datasheet.ciiva.com/46420/1678782-46420186.pdf?src-supplier=Element14; ComponentLink9URL: https://datasheet.ciiva.com/46420/1678782-46420186.pdf?src-supplier=Element14; ComponentLink10Description: https://datasheet.ciiva.com/16788/70260748-16788299.pdf?src-supplier=Allied+Electronics; ComponentLink10URL: https://datasheet.ciiva.com/16788/70260748-16788299.pdf?src-supplier=Allied+Electronics; ComponentLink11Description: https://datasheet.ciiva.com/7909/426256-7909229.pdf?src-supplier=Element14; ComponentLink11URL: https://datasheet.ciiva.com/7909/426256-7909229.pdf?src-supplier=Element14; ComponentLink12Description: https://datasheet.ciiva.com/15158/getdatasheetpartid-246555-15158845.pdf?src-supplier=Verical; ComponentLink12URL: https://datasheet.ciiva.com/15158/getdatasheetpartid-246555-15158845.pdf?src-supplier=Verical; ComponentLink13Description: http://datasheet.octopart.com/ERJ-6ENF1004V-Panasonic-datasheet-5313456.pdf?src-supplier=Farnell; ComponentLink13URL: http://datasheet.octopart.com/ERJ-6ENF1004V-Panasonic-datasheet-5313456.pdf?src-supplier=Farnell; ComponentLink14Description: http://datasheet.octopart.com/ERJ-6ENF1004V-Panasonic-datasheet-13266541.pdf?src-supplier=Allied+Electronics; ComponentLink14URL: http://datasheet.octopart.com/ERJ-6ENF1004V-Panasonic-datasheet-13266541.pdf?src-supplier=Allied+Electronics; ComponentLink15Description: http://datasheet.octopart.com/ERJ-6ENF1004V-Panasonic-datasheet-48891056.pdf?src-supplier=Newark; ComponentLink15URL: http://datasheet.octopart.com/ERJ-6ENF1004V-Panasonic-datasheet-48891056.pdf?src-supplier=Newark; ComponentLink16Description: http://datasheet.octopart.com/ERJ-6ENF1004V-Panasonic-datasheet-8853621.pdf?src-supplier=Future+Electronics; ComponentLink16URL: http://datasheet.octopart.com/ERJ-6ENF1004V-Panasonic-datasheet-8853621.pdf?src-supplier=Future+Electronics; ComponentLink17Description: http://datasheet.octopart.com/ERJ-6ENF1004V-Panasonic-datasheet-10025800.pdf?src-supplier=Future+Electronics; ComponentLink17URL: http://datasheet.octopart.com/ERJ-6ENF1004V-Panasonic-datasheet-10025800.pdf?src-supplier=Future+Electronics; ComponentLink18Description: http://datasheet.octopart.com/ERJ-6ENF1004V-Panasonic-datasheet-136608610.pdf?src-supplier=Farnell; ComponentLink18URL: http://datasheet.octopart.com/ERJ-6ENF1004V-Panasonic-datasheet-136608610.pdf?src-supplier=Farnell; ComponentLink19Description: http://datasheet.octopart.com/ERJ-6ENF1004V-Panasonic-datasheet-41391857.pdf?src-supplier=Electro+Sonic; ComponentLink19URL: http://datasheet.octopart.com/ERJ-6ENF1004V-Panasonic-datasheet-41391857.pdf?src-supplier=Electro+Sonic; ComponentLink20Description: http://datasheet.octopart.com/ERJ-6ENF1004V-Panasonic-datasheet-7538305.pdf?src-supplier=Jameco; ComponentLink20URL: http://datasheet.octopart.com/ERJ-6ENF1004V-Panasonic-datasheet-7538305.pdf?src-supplier=Jameco; ComponentLink21Description: http://datasheet.octopart.com/ERJ-6ENF1004V-Panasonic-datasheet-78375410.pdf; ComponentLink21URL: http://datasheet.octopart.com/ERJ-6ENF1004V-Panasonic-datasheet-78375410.pdf; ComponentLink22Description: http://datasheet.octopart.com/ERJ-6ENF1004V-Panasonic-datasheet-82288794.pdf?src-supplier=Future+Electronics; ComponentLink22URL: http://datasheet.octopart.com/ERJ-6ENF1004V-Panasonic-datasheet-82288794.pdf?src-supplier=Future+Electronics; ComponentLink23Description: http://datasheet.octopart.com/ERJ-6ENF1004V-Panasonic-datasheet-118602.pdf?src-supplier=Digi-Key; ComponentLink23URL: http://datasheet.octopart.com/ERJ-6ENF1004V-Panasonic-datasheet-118602.pdf?src-supplier=Digi-Key; ComponentLink24Description: http://datasheet.octopart.com/ERJ-6ENF1004V-Panasonic---ECG-datasheet-15994758.pdf?src-supplier=Farnell; ComponentLink24URL: http://datasheet.octopart.com/ERJ-6ENF1004V-Panasonic---ECG-datasheet-15994758.pdf?src-supplier=Farnell; Composition: Thick Film; Contact Plating: Tin; Depth: 1.25mm; Features: Automotive AEC-Q200; Height: 0.6mm; Lead Free: Lead Free; Length: 2mm; Library Name: Schlib1.SchLib; Manufacturer Part Number: ERJ-6ENF1004V; Max Operating Temperature: 155°C; Military Standard: Not; Min Operating Temperature: -55°C; Mount: Surface Mount; Number of Pins: 2; Number of Terminations: 2; Package Quantity: 1; Packaging: Tape and Reel; Power Rating: 125mW; Pricing 1: 1=0.10000, 10=0.06900, 100=0.02650, 1000=0.01166, 2500=0.01007 (USD); Radiation Hardening: No; REACH SVHC: No SVHC; Resistance: 1MR; Stock 1: 249385; Supplier 1: Digi-Key; Supplier Part Number 1: P1.00MCCT-ND; Temperature Coefficient: 100ppm/°C; Termination: SMD/SMT; Tolerance: 1%; Voltage Rating (DC): 150V; Width: 1.2mm
R2 = ERJ-6ENF1603V: Res Thick Film 0805 160K Ohm 1% 1/8W ±100ppm/°C Molded SMD Punched Carrier T/R; Manufacturer: Panasonic; Max Power Dissipation: 125mW; Voltage Rating: 150V; Case Code (Imperial): 0805; Case Code (Metric): 2012; Case/Package: 0805; Category: Resistor; Component Kind: Standard; ComponentLink1Description: https://datasheet.ciiva.com/22674/1678782-22674517.pdf?src-supplier=Element14; ComponentLink1URL: https://datasheet.ciiva.com/22674/1678782-22674517.pdf?src-supplier=Element14; ComponentLink2Description: https://datasheet.ciiva.com/46436/1678782-46436469.pdf?src-supplier=Element14; ComponentLink2URL: https://datasheet.ciiva.com/46436/1678782-46436469.pdf?src-supplier=Element14; ComponentLink3Description: https://datasheet.ciiva.com/46436/1678782-46436470.pdf?src-supplier=Element14; ComponentLink3URL: https://datasheet.ciiva.com/46436/1678782-46436470.pdf?src-supplier=Element14; ComponentLink4Description: https://datasheet.ciiva.com/17207/aoa0000ce2-17207337.pdf?src-supplier=Avnet+Europe; ComponentLink4URL: https://datasheet.ciiva.com/17207/aoa0000ce2-17207337.pdf?src-supplier=Avnet+Europe; ComponentLink5Description: https://datasheet.ciiva.com/9185/aoa0000ce2-9185402.pdf?src-supplier=Avnet; ComponentLink5URL: https://datasheet.ciiva.com/9185/aoa0000ce2-9185402.pdf?src-supplier=Avnet; ComponentLink6Description: https://datasheet.ciiva.com/22739/1678782-22739739.pdf?src-supplier=Element14; ComponentLink6URL: https://datasheet.ciiva.com/22739/1678782-22739739.pdf?src-supplier=Element14; ComponentLink7Description: https://datasheet.ciiva.com/24060/aoa0000ce2-24060654.pdf?src-supplier=Mouser; ComponentLink7URL: https://datasheet.ciiva.com/24060/aoa0000ce2-24060654.pdf?src-supplier=Mouser; ComponentLink8Description: http://datasheet.octopart.com/ERJ-6ENF1603V-Panasonic-datasheet-13266541.pdf?src-supplier=Newark; ComponentLink8URL: http://datasheet.octopart.com/ERJ-6ENF1603V-Panasonic-datasheet-13266541.pdf?src-supplier=Newark; ComponentLink9Description: http://datasheet.octopart.com/ERJ-6ENF1603V-Panasonic-datasheet-48891056.pdf?src-supplier=Farnell; ComponentLink9URL: http://datasheet.octopart.com/ERJ-6ENF1603V-Panasonic-datasheet-48891056.pdf?src-supplier=Farnell; ComponentLink10Description: http://datasheet.octopart.com/ERJ-6ENF1603V-Panasonic-datasheet-136608610.pdf?src-supplier=Farnell; ComponentLink10URL: http://datasheet.octopart.com/ERJ-6ENF1603V-Panasonic-datasheet-136608610.pdf?src-supplier=Farnell; ComponentLink11Description: http://datasheet.octopart.com/ERJ6ENF1603V-Panasonic---ECG-datasheet-5313456.pdf?src-supplier=Element14; ComponentLink11URL: http://datasheet.octopart.com/ERJ6ENF1603V-Panasonic---ECG-datasheet-5313456.pdf?src-supplier=Element14; ComponentLink12Description: http://datasheet.octopart.com/ERJ6ENF1603V-Panasonic---ECG-datasheet-8853621.pdf?src-supplier=Element14; ComponentLink12URL: http://datasheet.octopart.com/ERJ6ENF1603V-Panasonic---ECG-datasheet-8853621.pdf?src-supplier=Element14; ComponentLink13Description: http://datasheet.octopart.com/ERJ6ENF1603V-Panasonic---ECG-datasheet-10025800.pdf?src-supplier=Element14; ComponentLink13URL: http://datasheet.octopart.com/ERJ6ENF1603V-Panasonic---ECG-datasheet-10025800.pdf?src-supplier=Element14; ComponentLink14Description: http://datasheet.octopart.com/ERJ-6ENF1603V-Panasonic-datasheet-41391857.pdf?src-supplier=Electro+Sonic; ComponentLink14URL: http://datasheet.octopart.com/ERJ-6ENF1603V-Panasonic-datasheet-41391857.pdf?src-supplier=Electro+Sonic; ComponentLink15Description: http://datasheet.octopart.com/ERJ-6ENF1603V-Panasonic-datasheet-78375410.pdf; ComponentLink15URL: http://datasheet.octopart.com/ERJ-6ENF1603V-Panasonic-datasheet-78375410.pdf; ComponentLink16Description: http://datasheet.octopart.com/ERJ6ENF1603V-Panasonic---ECG-datasheet-15994758.pdf?src-supplier=Farnell; ComponentLink16URL: http://datasheet.octopart.com/ERJ6ENF1603V-Panasonic---ECG-datasheet-15994758.pdf?src-supplier=Farnell; Composition: Thick Film; Contact Plating: Tin; Depth: 1.25mm; Features: Automotive AEC-Q200; Height: 0.028inch; Length: 2mm; Library Name: Schlib1.SchLib; Manufacturer Part Number: ERJ-6ENF1603V; Max Operating Temperature: 155°C; Military Standard: Not; Min Operating Temperature: -55°C; Mount: Surface Mount; Number of Terminations: 2; Package Quantity: 1; Packaging: Tape and Reel; Power Rating: 125mW; Pricing 1: 1=0.10000, 10=0.07200, 100=0.02750, 1000=0.01210, 2500=0.01045 (USD); Radiation Hardening: No; REACH SVHC: No SVHC; Resistance: 160kR; Stock 1: 46420; Supplier 1: Digi-Key; Supplier Part Number 1: P160KCCT-ND; Temperature Coefficient: 100ppm/°C; Termination: SMD/SMT; Tolerance: 1%; Width: 0.049inch
R3 R4 = ERA-6AED331V: Res Thin Film 0805 330 Ohm 0.5% 0.125W(1/8W) ±25ppm/C Molded SMD Automotive Punched T/R; Manufacturer: Panasonic; Power Rating: 125mW; Temperature Coefficient: 25ppm/°C; Voltage Rating: 200V; Case Code (Imperial): 0805; Case Code (Metric): 2012; Case/Package: 0805; Category: Resistor; Component Kind: Standard; ComponentLink1Description: https://datasheet.ciiva.com/24071/aoa0000ce26-197354-24071480.pdf?src-supplier=Mouser; ComponentLink1URL: https://datasheet.ciiva.com/24071/aoa0000ce26-197354-24071480.pdf?src-supplier=Mouser; ComponentLink2Description: https://datasheet.ciiva.com/9182/aoa0000ce26-9182970.pdf?src-supplier=Avnet; ComponentLink2URL: https://datasheet.ciiva.com/9182/aoa0000ce26-9182970.pdf?src-supplier=Avnet; Contact Plating: Tin; Depth: 1.25mm; Height: 0.5mm; Lead Free: Lead Free; Length: 2mm; Library Name: Schlib1.SchLib; Manufacturer Part Number: ERA-6AED331V; Max Operating Temperature: 155°C; Military Standard: Not; Min Operating Temperature: -55°C; Mount: Surface Mount; Package Quantity: 5000; Packaging: Tape and Reel; Pricing 1: 1=0.10000, 10=0.09400, 25=0.06480, 50=0.05040, 100=0.03600, 250=0.02808, 500=0.02232, 1000=0.01584 (USD); Resistance: 330R; Resistor Type: Thin Film; RoHS: Yes; Stock 1: 11862; Supplier 1: Digi-Key; Supplier Part Number 1: P123837CT-ND; Termination: SMD/SMT; Tolerance: 0.5%; Width: 1.25mm
R5 R7 = ERA-6AED472V: Res Thin Film 0805 4.7K Ohm 0.5% 0.125W(1/8W) ±25ppm/C Molded SMD Automotive Punched T/R; Manufacturer: Panasonic; Power Rating: 125mW; Temperature Coefficient: 25ppm/°C; Voltage Rating: 100V; Case Code (Imperial): 0805; Case Code (Metric): 2012; Case/Package: 0805; Category: Chip SMD Resistors; Component Kind: Standard; ComponentLink1Description: https://datasheet.ciiva.com/24027/aoa0000ce26-32660-24027576.pdf?src-supplier=Mouser; ComponentLink1URL: https://datasheet.ciiva.com/24027/aoa0000ce26-32660-24027576.pdf?src-supplier=Mouser; Contact Plating: Tin; Depth: 1.25mm; Height: 0.5mm; Lead Free: Lead Free; Length: 2mm; Library Name: Schlib1.SchLib; Manufacturer Part Number: ERA-6AED472V; Max Operating Temperature: 155°C; Military Standard: Not; Min Operating Temperature: -55°C; Mount: Surface Mount; Package Quantity: 5000; Packaging: Tape and Reel; Pricing 1: 1=0.10000, 10=0.09400, 100=0.03600, 1000=0.01584, 2500=0.01368 (USD); Resistance: 4.7kR; Resistor Type: Metal Film,Thin Film; RoHS: Yes; Stock 1: 25343; Supplier 1: Digi-Key; Supplier Part Number 1: P123914CT-ND; Tolerance: 0.5%; Width: 1.25mm
R6 R8 R10 R11 = ERJ-PB6D1241V: RES SMD 1.24K OHM 0.5% 1/4W 0805; Manufacturer: Panasonic; Max Power Dissipation: 250mW; Temperature Coefficient: 50ppm/°C; Case Code (Imperial): 0805; Case Code (Metric): 2012; Case/Package: 0805; Category: Chip SMD Resistors; Component Kind: Standard; ComponentLink1Description: https://industrial.panasonic.com/sa/system/files/data/download/catalog/id_fixed-resistors_e_2.pdf?src-supplier=Digi-Key; ComponentLink1URL: https://industrial.panasonic.com/sa/system/files/data/download/catalog/id_fixed-resistors_e_2.pdf?src-supplier=Digi-Key; ComponentLink2Description: https://industrial.panasonic.com/cdbs/www-data/pdf/RDM0000/DMM0000COL5.pdf?src-supplier=Digi-Key; ComponentLink2URL: https://industrial.panasonic.com/cdbs/www-data/pdf/RDM0000/DMM0000COL5.pdf?src-supplier=Digi-Key; Features: Automotive AEC-Q200; Height - Seated (Max): 0.028inch; Length: 0.079inch; Library Name: Schlib1.SchLib; Manufacturer Part Number: ERJ-PB6D1241V; Max Operating Temperature: 155°C; Min Operating Temperature: -55°C; Number of Terminations: 2; Packaging: Tape and Reel; Pricing 1: 1=0.23000, 10=0.19100, 25=0.13920, 50=0.10100, 100=0.07480, 250=0.05744, 500=0.04524, 1000=0.03132 (USD); Resistance: 1.24kR; Resistor Type: Thick Film; RoHS: Yes; Stock 1: 777; Supplier 1: Digi-Key; Supplier Part Number 1: P21029CT-ND; Tolerance: 0.5%; Width: 0.049inch
R9 = CSR1206FKR250: Res Thick Film 1206 250m Ohm 1% 1/2W 100ppm/C Molded SMD Paper T/R; Manufacturer: Stackpole Electronics; Max Power Dissipation: 500mW; Temperature Coefficient: 100ppm/°C; Case Code (Imperial): 1206; Case Code (Metric): 3216; Case/Package: 1206; Category: Chip SMD Resistors; Component Kind: Standard; ComponentLink1Description: https://www.seielect.com/catalog/SEI-Packaging.pdf?src-supplier=Digi-Key; ComponentLink1URL: https://www.seielect.com/catalog/SEI-Packaging.pdf?src-supplier=Digi-Key; ComponentLink2Description: https://www.seielect.com/catalog/SEI-CSR_CSRN.pdf?src-supplier=Avnet+Asia; ComponentLink2URL: https://www.seielect.com/catalog/SEI-CSR_CSRN.pdf?src-supplier=Avnet+Asia; Contact Plating: Tin,Matte; Height: 0.026inch; Lead Free: Lead Free; Length: 0.12inch; Library Name: Schlib1.SchLib; Manufacturer Part Number: CSR1206FKR250; Max Operating Temperature: 155°C; Min Operating Temperature: -55°C; Mount: Surface Mount; Number of Terminations: 2; Packaging: Tape and Reel; Power Rating: 500mW; Pricing 1: 1=0.41000, 10=0.31100, 25=0.23640, 50=0.17560, 100=0.13050, 250=0.11024, 500=0.09450 (USD); Resistance: 250mR; Resistor Type: Thick Film; RoHS: Yes; Stock 1: 244191; Supplier 1: Digi-Key; Supplier Part Number 1: CSR1206FKR250CT-ND; Termination: Wraparound; Tolerance: 1%; Width: 0.061inch
SW1 = 100SP1T2B4M6QE: Switch Toggle ON None ON SPDT Round Lever PC Pins 5A 250VAC 28VDC PC Mount with Bracket; Manufacturer: E-Switch; Actuator Length: 6.46mm; Actuator Material: Brass; Actuator Type: Standard Round; Contact Resistance: 100mR; Electrical Life: 40000Cycles; Illumination Type: Non-Illuminated; Max Voltage Rating (AC): 250V; Max Voltage Rating (DC): 28V; Switch Function: On-On; Voltage Rating (AC): 120V; Category: Switch; Component Kind: Standard; ComponentLink1Description: https://datasheet.ciiva.com/2904/t111069-2904823.pdf?src-supplier=Digi-Key; ComponentLink1URL: https://datasheet.ciiva.com/2904/t111069-2904823.pdf?src-supplier=Digi-Key; ComponentLink2Description: https://datasheet.ciiva.com/16154/100-16154566.pdf?src-supplier=Onlinecomponents.com; ComponentLink2URL: https://datasheet.ciiva.com/16154/100-16154566.pdf?src-supplier=Onlinecomponents.com; ComponentLink3Description: http://datasheet.octopart.com/100SP1T2B4M6QE-E-Switch-datasheet-501321.pdf?src-supplier=Electro+Sonic; ComponentLink3URL: http://datasheet.octopart.com/100SP1T2B4M6QE-E-Switch-datasheet-501321.pdf?src-supplier=Electro+Sonic; ComponentLink4Description: http://datasheet.octopart.com/100SP1T2B4M6QE-E-Switch-datasheet-41351065.pdf?src-supplier=Farnell; ComponentLink4URL: http://datasheet.octopart.com/100SP1T2B4M6QE-E-Switch-datasheet-41351065.pdf?src-supplier=Farnell; ComponentLink5Description: http://datasheet.octopart.com/100SP1T2B4M6QE-E-Switch-datasheet-112052116.pdf?src-supplier=Electro+Sonic; ComponentLink5URL: http://datasheet.octopart.com/100SP1T2B4M6QE-E-Switch-datasheet-112052116.pdf?src-supplier=Electro+Sonic; ComponentLink6Description: http://datasheet.octopart.com/100SP1T2B4M6QE-E-Switch-datasheet-26830754.pdf?src-supplier=Electro+Sonic; ComponentLink6URL: http://datasheet.octopart.com/100SP1T2B4M6QE-E-Switch-datasheet-26830754.pdf?src-supplier=Electro+Sonic; ComponentLink7Description: http://datasheet.octopart.com/100SP1T2B4M6QE-E-Switch-datasheet-62101310.pdf?src-supplier=Electro+Sonic; ComponentLink7URL: http://datasheet.octopart.com/100SP1T2B4M6QE-E-Switch-datasheet-62101310.pdf?src-supplier=Electro+Sonic; Contact Material: Silver; Contact Plating: Silver; Current Rating: 5A; Housing Material: Stainless Steel; Lead Free: Lead Free; Length: 12.7mm; Library Name: Schlib1.SchLib; Manufacturer Part Number: 100SP1T2B4M6QE; Max Operating Temperature: 85°C; Min Operating Temperature: -30°C; Mount: Through Hole; Orientation: Right Angle; Package Quantity: 1; Packaging: Bulk; Pricing 1: 1=3.01000, 10=2.69700, 25=2.54800, 50=2.43620, 100=2.31200, 250=2.21256, 500=2.03852, 1000=1.93908 (USD); Stock 1: 3257; Supplier 1: Digi-Key; Supplier Part Number 1: EG2362-ND; Termination: PC Pin; Throw Configuration: SPDT; Voltage Rating (DC): 28V; Width: 6.86mm
U1 U2 = LDL1117S50R: LDL1117 Series 1.2 A 5 V High PSRR LDO Linear Voltage Regulator - SOT-223; Manufacturer: STMicroelectronics; Dropout Voltage: 600mV; Max Input Voltage: 18V; Min Output Voltage: 5V; Number of Regulators: 1; Output Configuration: Positive; Output Current: 1.2A; Output Type: Fixed; Power Supply Rejection Ratio (PSRR): 65dB; Quiescent Current: 500uA; Case/Package: TO-261-4; Category: IC; Component Kind: Standard; ComponentLink1Description: http://www.farnell.com/datasheets/2259188.pdf?src-supplier=Farnell; ComponentLink1URL: http://www.farnell.com/datasheets/2259188.pdf?src-supplier=Farnell; ComponentLink2Description: http://www.st.com/content/ccc/resource/technical/document/datasheet/group3/0e/5a/00/ca/10/1a/4f/a5/DM00366442/files/DM00366442.pdf/jcr:content/translations/en.DM00366442.pdf?src-supplier=Digi-Key; ComponentLink2URL: http://www.st.com/content/ccc/resource/technical/document/datasheet/group3/0e/5a/00/ca/10/1a/4f/a5/DM00366442/files/DM00366442.pdf/jcr:content/translations/en.DM00366442.pdf?src-supplier=Digi-Key; ComponentLink3Description: http://www.farnell.com/datasheets/2259188.pdf?src-supplier=Newark; ComponentLink3URL: http://www.farnell.com/datasheets/2259188.pdf?src-supplier=Newark; ComponentLink4Description: http://datasheet.octopart.com/LDL1117S50R-STMicroelectronics-datasheet-82510909.pdf?src-supplier=Farnell; ComponentLink4URL: http://datasheet.octopart.com/LDL1117S50R-STMicroelectronics-datasheet-82510909.pdf?src-supplier=Farnell; ComponentLink5Description: http://datasheet.octopart.com/LDL1117S50R-STMicroelectronics-datasheet-93404513.pdf?src-supplier=Ciiva+Crawler; ComponentLink5URL: http://datasheet.octopart.com/LDL1117S50R-STMicroelectronics-datasheet-93404513.pdf?src-supplier=Ciiva+Crawler; Library Name: Schlib1.SchLib; Manufacturer Part Number: LDL1117S50R; Max Operating Temperature: 125°C; Min Operating Temperature: -40°C; Mount: Surface Mount; Packaging: Tape and Reel; Pricing 1: 1=0.48000, 10=0.36000, 25=0.32440, 100=0.17700, 250=0.17112, 500=0.15340, 1000=0.11800 (USD); Stock 1: 653; Supplier 1: Digi-Key; Supplier Part Number 1: 497-17240-1-ND
U3 = MC33063ADR: 1.5-A peak boost/buck/inverting switching regulator 8-SOIC -40 to 85; Manufacturer: Texas Instruments; Max Input Voltage: 40V; Max Output Current: 750mA; Min Input Voltage: 3V; Min Output Voltage: 1.25V; Number of Outputs: 1; Output Current: 1.5A; Output Type: Adjustable; Quiescent Current: 4mA; Switching Frequency: 100kHz; Synchronous Rectifier: No; Topology: Flyback; Case/Package: D; Category: IC; Component Kind: Standard; ComponentLink1Description: https://datasheet.ciiva.com/41462/001014422-da-01-en-ic-reg-buck-boost-mc33063adr-soic-8-tid-41462284.pdf?src-supplier=Conrad; ComponentLink1URL: https://datasheet.ciiva.com/41462/001014422-da-01-en-ic-reg-buck-boost-mc33063adr-soic-8-tid-41462284.pdf?src-supplier=Conrad; ComponentLink2Description: https://datasheet.ciiva.com/26897/mc33063adr-26897702.pdf?src-supplier=IIIC; ComponentLink2URL: https://datasheet.ciiva.com/26897/mc33063adr-26897702.pdf?src-supplier=IIIC; ComponentLink3Description: https://datasheet.ciiva.com/7993/1862376-7993641.pdf?src-supplier=Element14; ComponentLink3URL: https://datasheet.ciiva.com/7993/1862376-7993641.pdf?src-supplier=Element14; ComponentLink4Description: https://datasheet.ciiva.com/7408/1862376-7408058.pdf?src-supplier=Newark; ComponentLink4URL: https://datasheet.ciiva.com/7408/1862376-7408058.pdf?src-supplier=Newark; ComponentLink5Description: https://datasheet.ciiva.com/46419/1803417-46419179.pdf?src-supplier=Element14; ComponentLink5URL: https://datasheet.ciiva.com/46419/1803417-46419179.pdf?src-supplier=Element14; ComponentLink6Description: https://datasheet.ciiva.com/2025/mc33063a-2025164.pdf?src-supplier=Digi-Key; ComponentLink6URL: https://datasheet.ciiva.com/2025/mc33063a-2025164.pdf?src-supplier=Digi-Key; ComponentLink7Description: https://datasheet.ciiva.com/2025/mc33063a-2025165.pdf?src-supplier=Digi-Key; ComponentLink7URL: https://datasheet.ciiva.com/2025/mc33063a-2025165.pdf?src-supplier=Digi-Key; ComponentLink8Description: https://datasheet.ciiva.com/2025/mc33063a-2025166.pdf?src-supplier=Digi-Key; ComponentLink8URL: https://datasheet.ciiva.com/2025/mc33063a-2025166.pdf?src-supplier=Digi-Key; ComponentLink9Description: https://octopart.com/click/track?ai=8629&ct=datasheets&sig=0128011&at=physicalpart&sid=370&ppid=525094&hlid=24898548&src-supplier=TI+store; ComponentLink9URL: https://octopart.com/click/track?ai=8629&ct=datasheets&sig=0128011&at=physicalpart&sid=370&ppid=525094&hlid=24898548&src-supplier=TI+store; ComponentLink10Description: http://datasheet.octopart.com/MC33063ADR-Texas-Instruments-datasheet-37140769.pdf?src-supplier=Farnell; ComponentLink10URL: http://datasheet.octopart.com/MC33063ADR-Texas-Instruments-datasheet-37140769.pdf?src-supplier=Farnell; ComponentLink11Description: http://datasheet.octopart.com/MC33063ADR-Texas-Instruments-datasheet-149237.pdf?src-supplier=TI+store; ComponentLink11URL: http://datasheet.octopart.com/MC33063ADR-Texas-Instruments-datasheet-149237.pdf?src-supplier=TI+store; ComponentLink12Description: http://datasheet.octopart.com/MC33063ADR-Texas-Instruments-datasheet-149238.pdf?src-supplier=TI+store; ComponentLink12URL: http://datasheet.octopart.com/MC33063ADR-Texas-Instruments-datasheet-149238.pdf?src-supplier=TI+store; ComponentLink13Description: http://datasheet.octopart.com/MC33063ADR-Texas-Instruments-datasheet-149239.pdf?src-supplier=TI+store; ComponentLink13URL: http://datasheet.octopart.com/MC33063ADR-Texas-Instruments-datasheet-149239.pdf?src-supplier=TI+store; ComponentLink14Description: http://datasheet.octopart.com/MC33063ADR-Texas-Instruments-datasheet-149240.pdf?src-supplier=TI+store; ComponentLink14URL: http://datasheet.octopart.com/MC33063ADR-Texas-Instruments-datasheet-149240.pdf?src-supplier=TI+store; ComponentLink15Description: http://datasheet.octopart.com/MC33063ADR-Texas-Instruments-datasheet-149241.pdf?src-supplier=TI+store; ComponentLink15URL: http://datasheet.octopart.com/MC33063ADR-Texas-Instruments-datasheet-149241.pdf?src-supplier=TI+store; ComponentLink16Description: http://datasheet.octopart.com/MC33063ADR-Texas-Instruments-datasheet-10254267.pdf?src-supplier=TI+store; ComponentLink16URL: http://datasheet.octopart.com/MC33063ADR-Texas-Instruments-datasheet-10254267.pdf?src-supplier=TI+store; ComponentLink17Description: http://sigma.octopart.com/49249642/technical_drawing/Texas-Instruments-MC33063ADR.pdf?src-supplier=TI+store; ComponentLink17URL: http://sigma.octopart.com/49249642/technical_drawing/Texas-Instruments-MC33063ADR.pdf?src-supplier=TI+store; ComponentLink18Description: http://datasheet.octopart.com/MC33063ADR-Texas-Instruments-datasheet-12606816.pdf?src-supplier=Farnell; ComponentLink18URL: http://datasheet.octopart.com/MC33063ADR-Texas-Instruments-datasheet-12606816.pdf?src-supplier=Farnell; ComponentLink19Description: http://datasheet.octopart.com/MC33063ADR-Texas-Instruments-datasheet-81639027.pdf?src-supplier=Farnell; ComponentLink19URL: http://datasheet.octopart.com/MC33063ADR-Texas-Instruments-datasheet-81639027.pdf?src-supplier=Farnell; ComponentLink20Description: http://datasheet.octopart.com/MC33063ADR-Texas-Instruments-datasheet-23714746.pdf?src-supplier=Newark; ComponentLink20URL: http://datasheet.octopart.com/MC33063ADR-Texas-Instruments-datasheet-23714746.pdf?src-supplier=Newark; ComponentLink21Description: http://datasheet.octopart.com/MC33063ADR-Texas-Instruments-datasheet-33038661.pdf?src-supplier=Element14; ComponentLink21URL: http://datasheet.octopart.com/MC33063ADR-Texas-Instruments-datasheet-33038661.pdf?src-supplier=Element14; ComponentLink22Description: http://datasheet.octopart.com/MC33063ADR.-Texas-Instruments-datasheet-58444985.pdf?src-supplier=Element14; ComponentLink22URL: http://datasheet.octopart.com/MC33063ADR.-Texas-Instruments-datasheet-58444985.pdf?src-supplier=Element14; ComponentLink23Description: http://datasheet.octopart.com/MC33063ADR.-Texas-Instruments-datasheet-65572232.pdf?src-supplier=Element14; ComponentLink23URL: http://datasheet.octopart.com/MC33063ADR.-Texas-Instruments-datasheet-65572232.pdf?src-supplier=Element14; ComponentLink24Description: http://datasheet.octopart.com/MC33063ADR-Texas-Instruments-datasheet-12052.pdf?src-supplier=Newark; ComponentLink24URL: http://datasheet.octopart.com/MC33063ADR-Texas-Instruments-datasheet-12052.pdf?src-supplier=Newark; ComponentLink25Description: http://datasheet.octopart.com/MC33063ADR-Texas-Instruments-datasheet-137654.pdf?src-supplier=Digi-Key; ComponentLink25URL: http://datasheet.octopart.com/MC33063ADR-Texas-Instruments-datasheet-137654.pdf?src-supplier=Digi-Key; ComponentLink26Description: http://datasheet.octopart.com/MC33063ADR-Texas-Instruments-datasheet-8883959.pdf?src-supplier=Element14; ComponentLink26URL: http://datasheet.octopart.com/MC33063ADR-Texas-Instruments-datasheet-8883959.pdf?src-supplier=Element14; ComponentLink27Description: http://datasheet.octopart.com/MC33063ADR-Texas-Instruments-datasheet-11768196.pdf?src-supplier=Arrow; ComponentLink27URL: http://datasheet.octopart.com/MC33063ADR-Texas-Instruments-datasheet-11768196.pdf?src-supplier=Arrow; ComponentLink28Description: http://datasheet.octopart.com/MC33063ADR-Texas-Instruments-datasheet-14045796.pdf?src-supplier=IIIC; ComponentLink28URL: http://datasheet.octopart.com/MC33063ADR-Texas-Instruments-datasheet-14045796.pdf?src-supplier=IIIC; Contact Plating: Gold; Lead Free: Lead Free; Library Name: Schlib1.SchLib; Manufacturer Part Number: MC33063ADR; Max Operating Temperature: 85°C; Max Output Voltage: 40V; Min Operating Temperature: -40°C; Number of Pins: 8; Output Voltage: 40V; Package Quantity: 1; Packaging: Tape and Reel; Pricing 1: 1=0.44000, 10=0.37500, 25=0.35000, 100=0.26000, 250=0.22000, 500=0.21000, 1000=0.15500 (USD); REACH SVHC: No SVHC; Stock 1: 130093; Supplier 1: Digi-Key; Supplier Part Number 1: 296-17763-1-ND; Termination: SMD/SMT; Weight: 0.002561oz
